# Altium schematic sheet Sheet2.SchDoc(Sheet2)
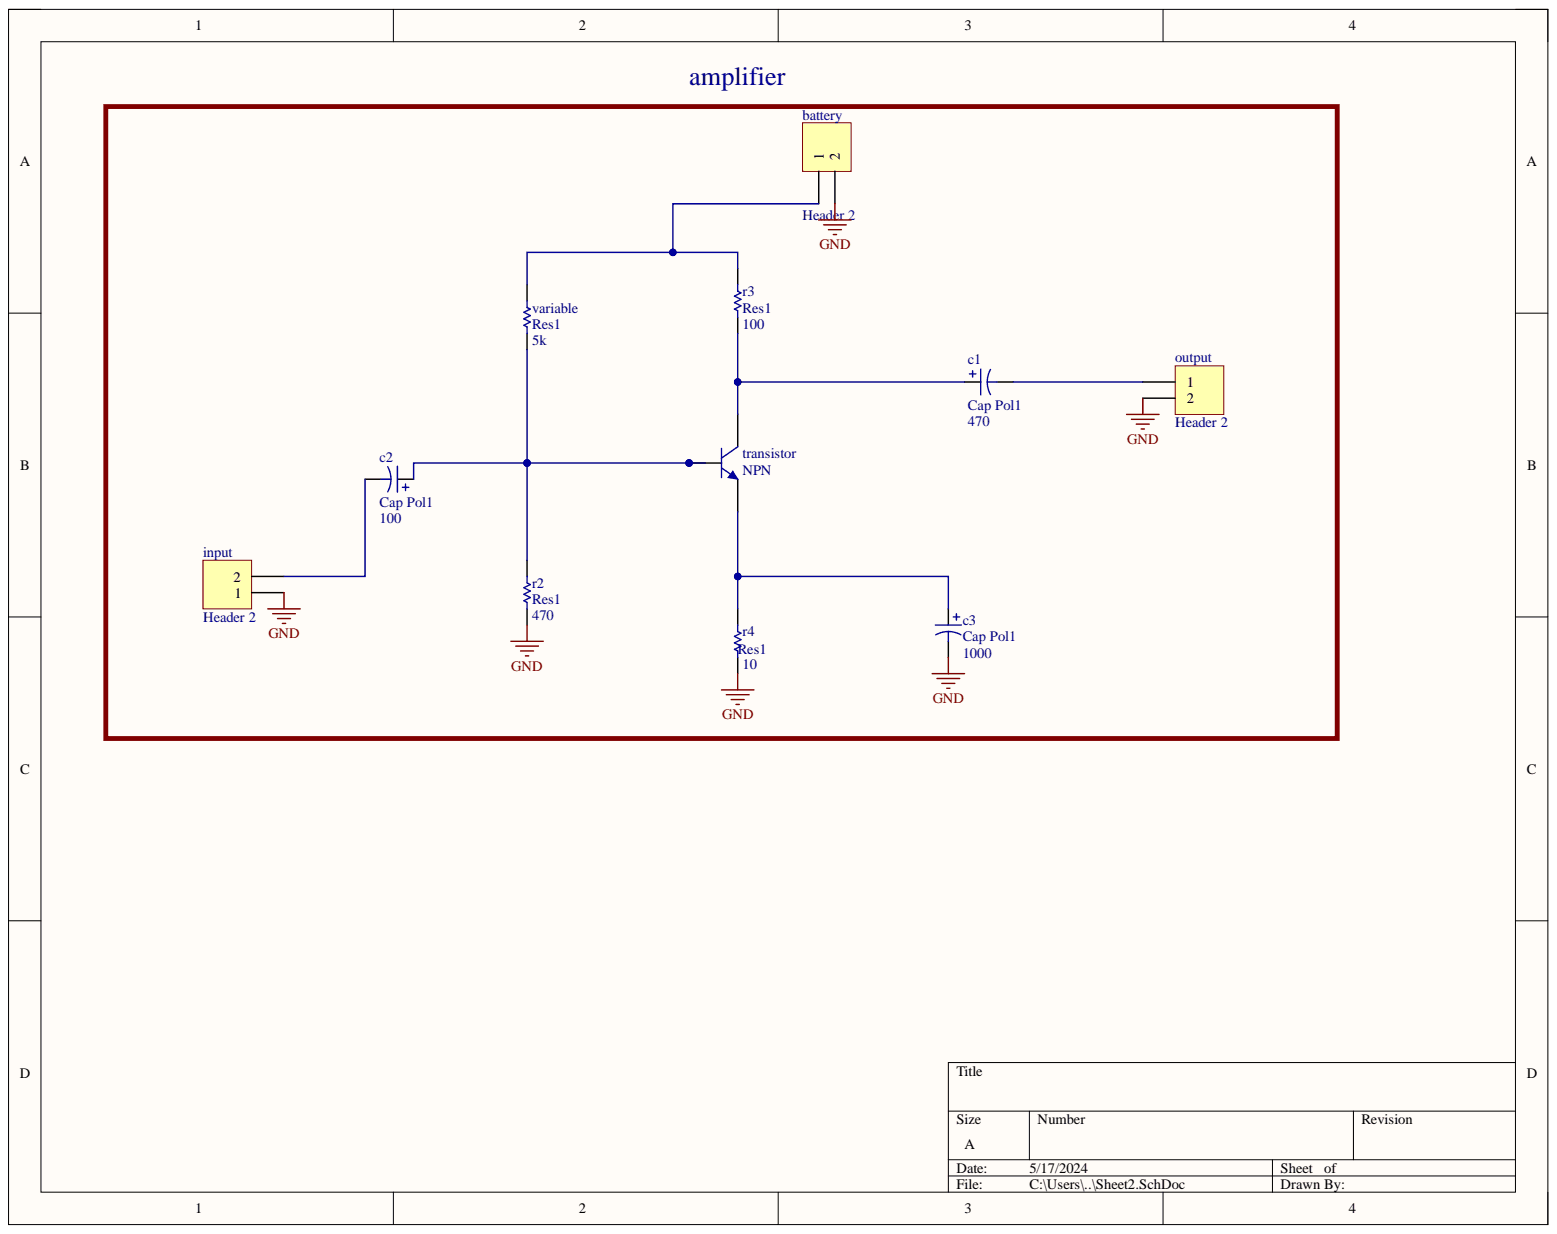
[diagram p0: Sheet2.SchDoc]

## Parts
battery  Header 2  [HDR1X2]
c1  Cap Pol1  value 470  [RB7.6-15]
c2  Cap Pol1  value 100  [RB7.6-15]
c3  Cap Pol1  value 1000  [RB7.6-15]
input  Header 2  [HDR1X2]
output  Header 2  [HDR1X2]
r2  Res1  value 470  [AXIAL-0.3]
r3  Res1  value 100  [AXIAL-0.3]
r4  Res1  value 10  [AXIAL-0.3]
transistor  NPN  [TO-226-AA]
variable  Res1  value 5k  [AXIAL-0.3]

## Nets
GND: battery.2 c3.2 input.1 output.2 r2.2 r4.2
Netbattery_1: battery.1 r3.1 variable.1
Netc1_1: c1.1 r3.2 transistor.1
Netc1_2: c1.2 output.1
Netc2_1: c2.1 r2.1 transistor.2 variable.2
Netc2_2: c2.2 input.2
Netc3_1: c3.1 r4.1 transistor.3

## Library data of the parts
battery input output = Header 2: Header, 2-Pin; Component Kind: Standard; LatestRevisionDate: 17-Jul-2002; LatestRevisionNote: Re-released for DXP Platform.; Library Name: Miscellaneous Connectors.IntLib; Signal Integrity: Connector; Publisher: Altium Limited
c1-c3 = Cap Pol1: Polarized Capacitor (Radial); Component Kind: Standard; LatestRevisionDate: 17-Jul-2002; LatestRevisionNote: Re-released for DXP Platform.; Library Name: Miscellaneous Devices.IntLib; PackageDescription: Polarized Capacitor (Radial); 2 Leads; PackageReference: RB7.6-15; Published: 8-Jun-2000; Signal Integrity: Cap Pol; Simulation: CAP; Publisher: Altium Limited
r2-r4 variable = Res1: Resistor; Component Kind: Standard; LatestRevisionDate: 17-Jul-2002; LatestRevisionNote: Re-released for DXP Platform.; Library Name: Miscellaneous Devices.IntLib; PackageDescription: Axial Device, Thru-Hole; 2 Leads; 0.3 in Pin Spacing; PackageReference: AXIAL-0.3; Published: 8-Jun-2000; Signal Integrity: Res; Simulation: RESISTOR; Publisher: Altium Limited
transistor = NPN: NPN Bipolar Transistor; Code_JEDEC: TO-226-AA; Component Kind: Standard; LatestRevisionDate: 17-Jul-2002; LatestRevisionNote: Re-released for DXP Platform.; Library Name: Miscellaneous Devices.IntLib; PackageDescription: TO, Flat Index; 3 In-Line, Axial Leads; Body Dia. 4.8mm; Leads 0.55 x 0.51 mm (max); PackageReference: TO-226-AA; Published: 8-Jun-2000; Signal Integrity: NPN; Simulation: NPN; Publisher: Altium Limited
